# Altium schematic sheet 通信.SchDoc(通信)
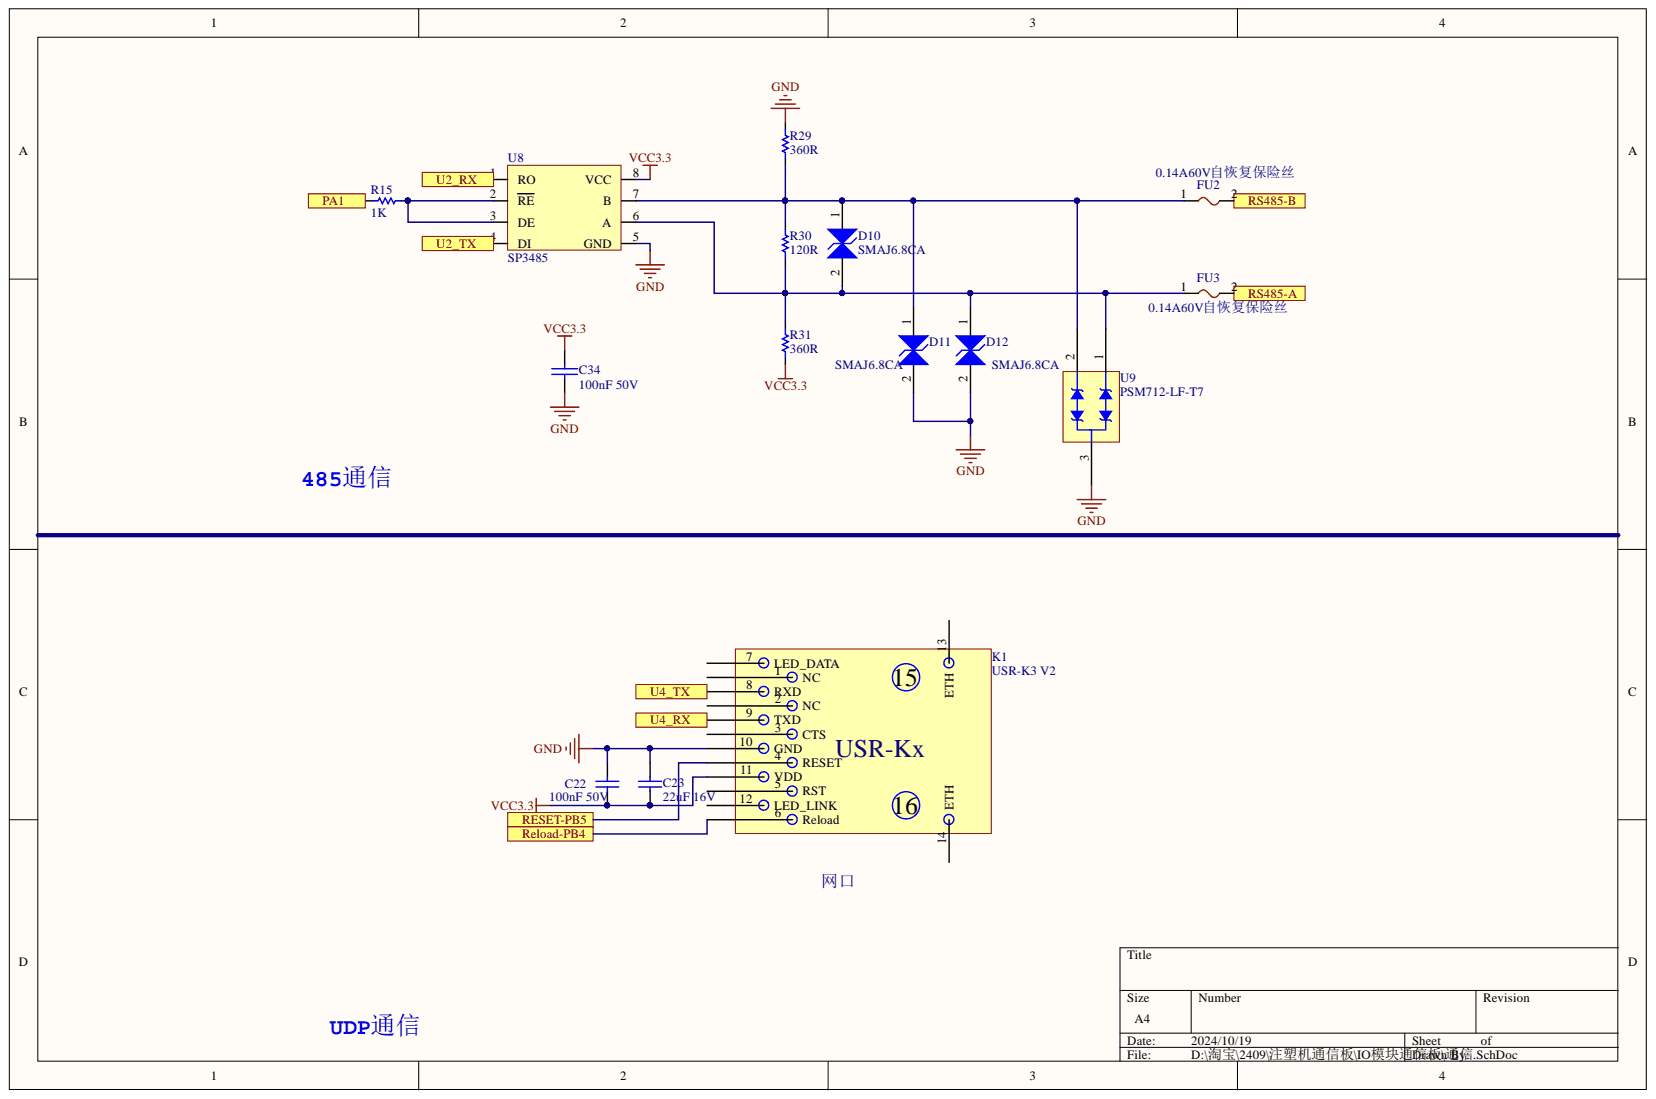
[diagram p0: 通信.SchDoc]

## Parts
C22  100nF 50V  [0603_C]
C23  22uF 16V  [0805_C]
C34  100nF 50V  [0603_C]
D10  SMAJ6.8CA  [SMA(DO-214AC)_S1]
D11  SMAJ6.8CA  [SMA(DO-214AC)_S1]
D12  SMAJ6.8CA  [SMA(DO-214AC)_S1]
FU2  0.14A60V自恢复保险丝  [1812_R]
FU3  0.14A60V自恢复保险丝  [1812_R]
K1  USR-K3 V2  [USR-K3 V2]
R15  1K  [0603_R]
R29  360R  [0603_R]
R30  120R  [0603_R]
R31  360R  [0603_R]
U8  SP3485  [SOIC-8_150mil]
U9  PSM712-LF-T7  [SOT-23(SOT-23-3)]

## Ports
PA1, Reload-PB4, RESET-PB5, RS485-A, RS485-B, U2_RX, U2_TX, U4_RX, U4_TX

## Nets
GND: C22.2 C23.2 C34.2 D11.2 D12.2 K1.10(GND) R29.2 U8.5(GND) U9.3
VCC3.3: C22.1 C23.1 C34.1 K1.11(VDD) R31.1 U8.8(VCC)
PA1: R15.2 ; port PA1
Reload-PB4: K1.6(Reload) ; port Reload-PB4
RESET-PB5: K1.4(RESET) ; port RESET-PB5
RS485-A: FU3.2 ; port RS485-A
RS485-B: FU2.2 ; port RS485-B
U2_RX: U8.1(RO) ; port U2_RX
U2_TX: U8.4(DI) ; port U2_TX
U4_RX: K1.9(TXD) ; port U4_RX
U4_TX: K1.8(RXD) ; port U4_TX
NetD10_1: D10.1 D11.1 FU2.1 R29.1 R30.1 U8.7(B) U9.2
NetD10_2: D10.2 D12.1 FU3.1 R30.2 R31.2 U8.6(A) U9.1
NetR15_1: R15.1 U8.2(RE) U8.3(DE)

Not connected: K1 1(NC) 2(NC) 3(CTS) 5(RST) 7(LED_DATA) 12(LED_LINK) 13(ETH) 14(ETH)

## Library data of the parts
C22 = 0402 100nF (104) 10% 16V: 贴片电容; SuppliersPartNumber: C1525; Supplier: LC; Package: 0402; Library Name: Cap.SchLib; ComponentLink1URL: http://www.szlcsc.com/product/details_1877.html; ComponentLink1Description: 供应商链接; Component Kind: Standard
C23 = 0603 10uF (106) 10% 10V: 贴片电容; SuppliersPartNumber: C19702; Supplier: LC; Package: 0603; Library Name: Cap.SchLib; ComponentLink1URL: http://www.szlcsc.com/product/details_20411.html; ComponentLink1Description: 供应商链接; Component Kind: Standard
C34 = CAP: Component Kind: Standard; Library Name: wgh元件库.SCHLIB
D10-D12 = SMB/DO-214AA P6SMB6.8CA: TVS; SuppliersPartNumber: C78395; Supplier: LC; Package: SMB/DO-214AA; Library Name: Diode.SchLib; ComponentLink1URL: http://www.szlcsc.com/product/details_79530.html; ComponentLink1Description: 供应商链接; Component Kind: Standard
FU2 FU3 = 自恢复保险丝: Component Kind: Standard; Library Name: wgh元件库.SCHLIB
R15 R29-R31 = Res: Resistor; Value: 68.1K; Simulation: RESISTOR; Signal Integrity: Res; Published: 8-Jun-2000; PackageReference: AXIAL-0.3; PackageDescription: Axial Device, Thru-Hole; 2 Leads; 0.3 in Pin Spacing; LatestRevisionNote: Re-released for DXP Platform.; LatestRevisionDate: 17-Jul-2002; Component Kind: Standard; Publisher: Altium Limited; Library Name: MAMA.SCHLIB
U9 = PSM712-LF-T7_[C32677]: TVS; SuppliersPartNumber: C32677; Supplier: LC; Package: SOT-23(SOT-23-3); Library Name: IC.SchLib; ComponentLink1URL: http://www.szlcsc.com/product/details_33642.html; ComponentLink1Description: 供应商链接; Component Kind: Standard
K1 = USR-K3 V2: Component Kind: Standard; Library Name: LDGlib.SchLib
U8 = SP3485: Component Kind: Standard; Library Name: wgh元件库.SCHLIB
